# Altium schematic sheet Display.SchDoc
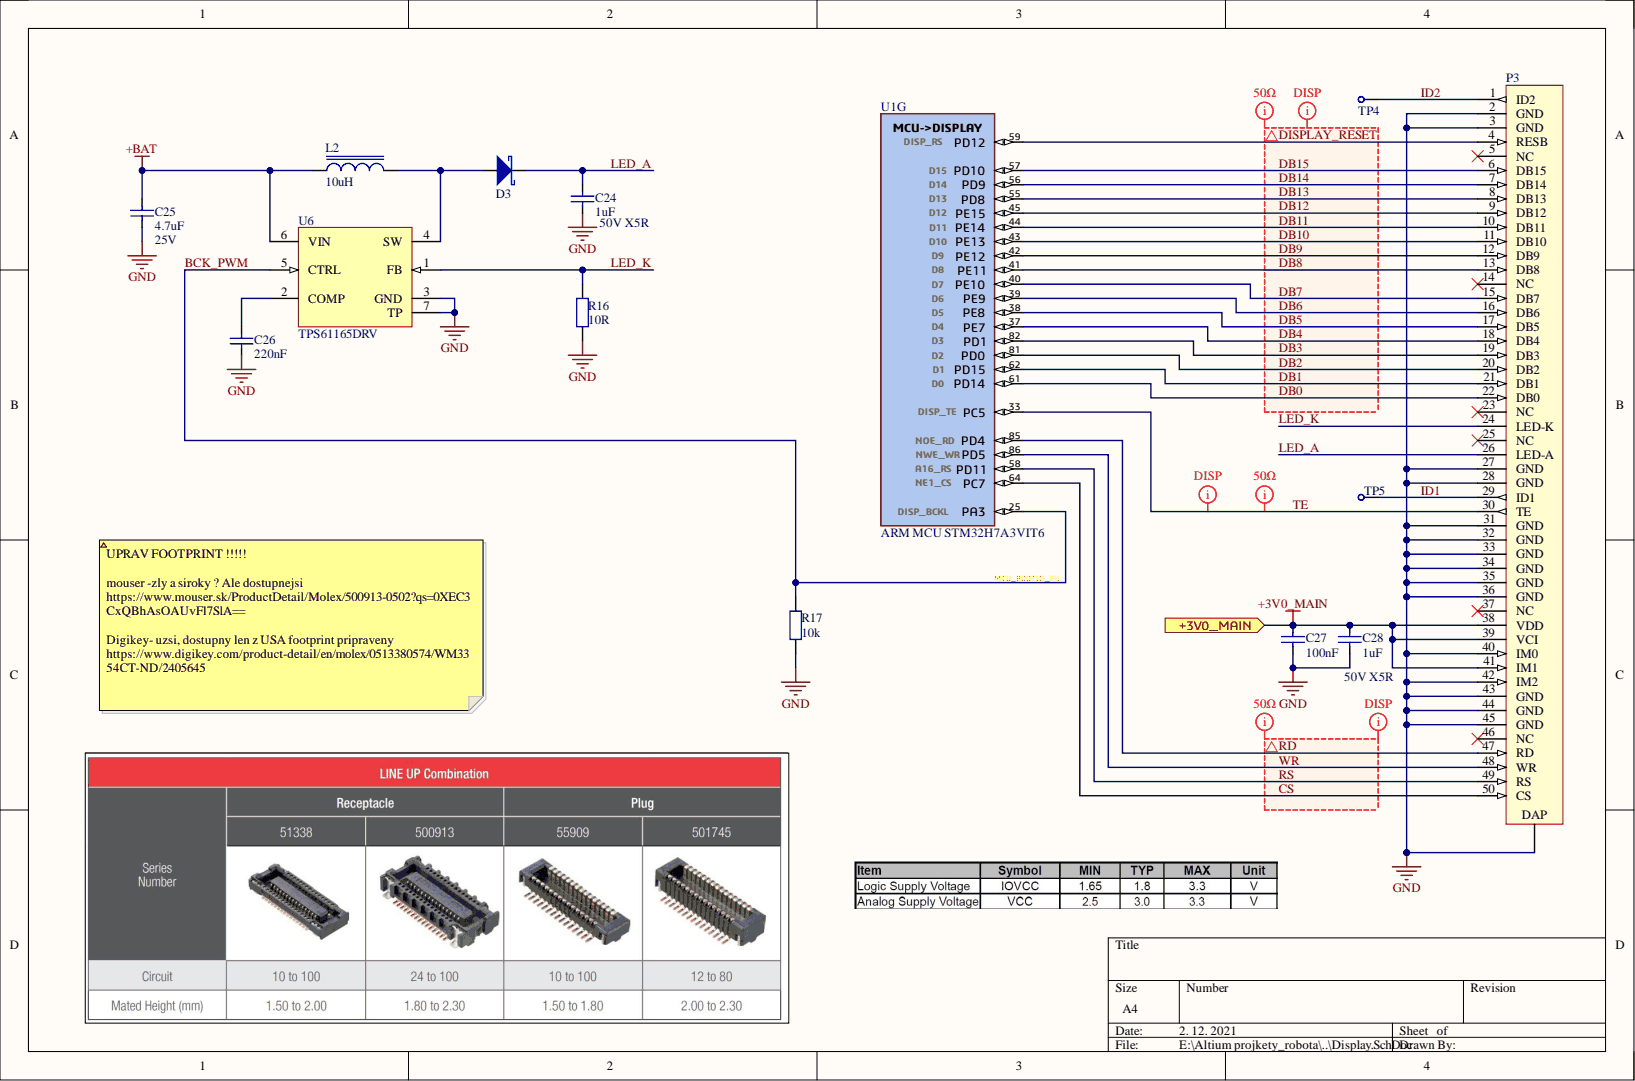
[diagram p0: Display.SchDoc]

## Parts
C24  1uF  Voltage Rating (DC): 50V  [CAPACITOR_0603_(1608)]
C25  4.7uF  Voltage: 25V  [CAPACITOR_0603_(1608)]
C26  220nF  [CAPACITOR_0603_(1608)]
C27  100nF  [CAPACITOR_0402_(1005)]
C28  1uF  Dielectric: X5R  Voltage Rating: 50V X5R  Voltage Rating (DC): 50V  [CAPACITOR_0603_(1608)]
D3  NSR10F30NXT5G  [D_DSN2_(0502)]  (value not drawn)
L2  10uH  [INDUCTOR_1210_(3225)]
P3  Connector  [MOLEX 500913-0502]  (value not drawn)
R16  10R  [RESISTOR_0603_(1608)]
R17  10k  [RESISTOR_0603_(1608)]
TP4  test point  [TP]  (value not drawn)  (no BOM)
TP5  test point  [TP]  (value not drawn)  (no BOM)
U1  ARM MCU STM32H7A3VIT6  Manufacturer Part Number: STM32H7A3VIT6  [STM-LQFP100_N - Edited]  (part G)
U6  TPS61165DRV  [WSON-6]

## Ports
+3V0_MAIN

## Nets
+BAT: C25.1 L2.1 U6.6(VIN)
GND: C24.2 C25.2 C26.1 C27.1 C28.2 P3.0 P3.2(GND) P3.3(GND) P3.27(GND) P3.28(GND) P3.31(GND) P3.32(GND) P3.33(GND) P3.34(GND) P3.35(GND) P3.36(GND) P3.40(IM0) P3.42(IM2) P3.43(GND) P3.44(GND) P3.45(GND) R16.1 R17.2 U6.3(GND) U6.7(TP)
+3V0_MAIN: C27.2 C28.1 P3.38(VDD) P3.39(VCI) P3.41(IM1) ; port +3V0_MAIN
BCK_PWM: R17.1 U1.25(PA3) U6.5(CTRL)
CS: P3.50(CS) U1.64(PC7)
DB0: P3.22(DB0) U1.61(PD14)
DB1: P3.21(DB1) U1.62(PD15)
DB2: P3.20(DB2) U1.81(PD0)
DB3: P3.19(DB3) U1.82(PD1)
DB4: P3.18(DB4) U1.37(PE7)
DB5: P3.17(DB5) U1.38(PE8)
DB6: P3.16(DB6) U1.39(PE9)
DB7: P3.15(DB7) U1.40(PE10)
DB8: P3.13(DB8) U1.41(PE11)
DB9: P3.12(DB9) U1.42(PE12)
DB10: P3.11(DB10) U1.43(PE13)
DB11: P3.10(DB11) U1.44(PE14)
DB12: P3.9(DB12) U1.45(PE15)
DB13: P3.8(DB13) U1.55(PD8)
DB14: P3.7(DB14) U1.56(PD9)
DB15: P3.6(DB15) U1.57(PD10)
DISPLAY_RESET: P3.4(RESB) U1.59(PD12)
ID1: P3.29(ID1) TP5.1
ID2: P3.1(ID2) TP4.1
LED_A: C24.1 D3.1 P3.26(LED-A)
LED_K: P3.24(LED-K) R16.2 U6.1(FB)
RD: P3.47(RD) U1.85(PD4)
RS: P3.49(RS) U1.58(PD11)
TE: P3.30(TE) U1.33(PC5)
WR: P3.48(WR) U1.86(PD5)
NetC26_2: C26.2 U6.2(COMP)
NetD3_2: D3.2 L2.2 U6.4(SW)

Not connected: P3 5(NC) 14(NC) 23(NC) 25(NC) 37(NC) 46(NC)

## Library data of the parts
C24 = CAPACITOR: Manufacturer: Taiyo Yuden; Manufacturer Part Number 1: UMK107BJ105KA-T; Dielectric: X5R; ESR: 0.28R; Tolerance: 10%; Voltage Rating: 50V X5R; Capacitance: 1uF; Case Code (Imperial): 0603; Case Code (Metric): 1608; Case/Package: 0603; Component Kind: Standard; ComponentLink1Description: https://datasheet.ciiva.com/5744/1697715-5744913.pdf?src-supplier=Farnell; ComponentLink1URL: https://datasheet.ciiva.com/5744/1697715-5744913.pdf?src-supplier=Farnell; ComponentLink2Description: https://datasheet.ciiva.com/11601/umk107bj105ka-t-ss-11601811.pdf?src-supplier=Avnet; ComponentLink2URL: https://datasheet.ciiva.com/11601/umk107bj105ka-t-ss-11601811.pdf?src-supplier=Avnet; ComponentLink3Description: https://datasheet.ciiva.com/15922/336umk107bj105ka-t-15922611.pdf?src-supplier=Onlinecomponents.com; ComponentLink3URL: https://datasheet.ciiva.com/15922/336umk107bj105ka-t-15922611.pdf?src-supplier=Onlinecomponents.com; ComponentLink4Description: https://datasheet.ciiva.com/134/umk107bj105ka-t-ss-134740.pdf?src-supplier=Digi-Key; ComponentLink4URL: https://datasheet.ciiva.com/134/umk107bj105ka-t-ss-134740.pdf?src-supplier=Digi-Key; ComponentLink5Description: https://datasheet.ciiva.com/30199/43umk107bj105ka-t-30199791.pdf?src-supplier=Onlinecomponents.com; ComponentLink5URL: https://datasheet.ciiva.com/30199/43umk107bj105ka-t-30199791.pdf?src-supplier=Onlinecomponents.com; ComponentLink6Description: https://datasheet.ciiva.com/22694/1697715-22694278.pdf?src-supplier=Element14; ComponentLink6URL: https://datasheet.ciiva.com/22694/1697715-22694278.pdf?src-supplier=Element14; ComponentLink7Description: https://datasheet.ciiva.com/7975/1697715-7975608.pdf?src-supplier=Element14; ComponentLink7URL: https://datasheet.ciiva.com/7975/1697715-7975608.pdf?src-supplier=Element14; ComponentLink8Description: https://datasheet.ciiva.com/7975/mlcc-all-e-7975898.pdf?src-supplier=Element14; ComponentLink8URL: https://datasheet.ciiva.com/7975/mlcc-all-e-7975898.pdf?src-supplier=Element14; ComponentLink9Description: https://datasheet.ciiva.com/5427/mlcc-all-e-541559-5427036.pdf?src-supplier=Mouser; ComponentLink9URL: https://datasheet.ciiva.com/5427/mlcc-all-e-541559-5427036.pdf?src-supplier=Mouser; Contact Plating: Nickel; Depth: 0.8mm; Description: CAPACITOR; Halogen Free: Halogen Free; Height: 0.8mm; Length: 1.6mm; Max Operating Temperature: 85°C; Min Operating Temperature: -55°C; Mount: Surface Mount; Number of Pins: 2; Package Quantity: 1; Packaging: Tape and Reel; Pricing 1: 10=0,14300, 100=0,06560, 500=0,04260, 1000=0,03940, 2000=0,03750, 4000=0,02830, 20000=0,02630 (EUR); Radiation Hardening: No; REACH SVHC: Unknown; RoHS: Yes; Stock 1: 372983; Supplier 1: Farnell; Supplier Part Number 1: 2112858; Termination: SMD/SMT; Thickness: 0.035inch; Width: 0.031inch; Library Name: RLCFB.SchLib
C25 = CAPACITOR: Manufacturer: Murata; Manufacturer Part Number 1: GRM188R61E475KE11D; Dielectric: X5R; ESR: 0.28R; Material: Ceramic; Tolerance: 10%; Capacitance: 4.7uF; Case Code (Imperial): 0603; Case Code (Metric): 1608; Case/Package: 0603; Component Kind: Standard; ComponentLink1Description: https://datasheet.ciiva.com/46444/1769893-46444982.pdf?src-supplier=Element14; ComponentLink1URL: https://datasheet.ciiva.com/46444/1769893-46444982.pdf?src-supplier=Element14; ComponentLink2Description: https://datasheet.ciiva.com/46444/1769893-46444983.pdf?src-supplier=Element14; ComponentLink2URL: https://datasheet.ciiva.com/46444/1769893-46444983.pdf?src-supplier=Element14; ComponentLink3Description: https://datasheet.ciiva.com/14891/partnumbering-e-01-14891102.pdf?src-supplier=Digi-Key; ComponentLink3URL: https://datasheet.ciiva.com/14891/partnumbering-e-01-14891102.pdf?src-supplier=Digi-Key; ComponentLink4Description: https://datasheet.ciiva.com/14891/partnumbering-e-01-14891103.pdf?src-supplier=Digi-Key; ComponentLink4URL: https://datasheet.ciiva.com/14891/partnumbering-e-01-14891103.pdf?src-supplier=Digi-Key; Depth: 0.8mm; Description: CAPACITOR; Height: 0.8mm; Lead Free: Lead Free; Length: 1.6mm; Max Operating Temperature: 85°C; Min Operating Temperature: -55°C; Mount: Surface Mount; Package Quantity: 4000; Packaging: Cut Tape; Pricing 1: 10=0,35700, 100=100,00000, 500=0,15900, 1000=0,12800, 2000=0,10200 (CHF); REACH SVHC: No SVHC; RoHS: Yes; Stock 1: 95119; Supplier 1: Farnell; Supplier Part Number 1: 2426959; Thickness: 0.031inch; Voltage Rating: 25V; Voltage Rating (DC): 25V; Width: 0.031inch; Library Name: RLCFB.SchLib
C26 C27 = CAPACITOR: ESR: 0.28R; Voltage Rating: 10V; Component Kind: Standard; Description: CAPACITOR; Library Name: RLCFB.SchLib
  C26: Capacitance: 220nF
  C27: Capacitance: 100nF
C28 = CAPACITOR: Manufacturer: Taiyo Yuden; Manufacturer Part Number 1: UMK107BJ105KA-T; ESR: 0.28R; Tolerance: 10%; Capacitance: 1uF; Case Code (Imperial): 0603; Case Code (Metric): 1608; Case/Package: 0603; Component Kind: Standard; ComponentLink1Description: https://datasheet.ciiva.com/5744/1697715-5744913.pdf?src-supplier=Farnell; ComponentLink1URL: https://datasheet.ciiva.com/5744/1697715-5744913.pdf?src-supplier=Farnell; ComponentLink2Description: https://datasheet.ciiva.com/11601/umk107bj105ka-t-ss-11601811.pdf?src-supplier=Avnet; ComponentLink2URL: https://datasheet.ciiva.com/11601/umk107bj105ka-t-ss-11601811.pdf?src-supplier=Avnet; ComponentLink3Description: https://datasheet.ciiva.com/15922/336umk107bj105ka-t-15922611.pdf?src-supplier=Onlinecomponents.com; ComponentLink3URL: https://datasheet.ciiva.com/15922/336umk107bj105ka-t-15922611.pdf?src-supplier=Onlinecomponents.com; ComponentLink4Description: https://datasheet.ciiva.com/134/umk107bj105ka-t-ss-134740.pdf?src-supplier=Digi-Key; ComponentLink4URL: https://datasheet.ciiva.com/134/umk107bj105ka-t-ss-134740.pdf?src-supplier=Digi-Key; ComponentLink5Description: https://datasheet.ciiva.com/30199/43umk107bj105ka-t-30199791.pdf?src-supplier=Onlinecomponents.com; ComponentLink5URL: https://datasheet.ciiva.com/30199/43umk107bj105ka-t-30199791.pdf?src-supplier=Onlinecomponents.com; ComponentLink6Description: https://datasheet.ciiva.com/22694/1697715-22694278.pdf?src-supplier=Element14; ComponentLink6URL: https://datasheet.ciiva.com/22694/1697715-22694278.pdf?src-supplier=Element14; ComponentLink7Description: https://datasheet.ciiva.com/7975/1697715-7975608.pdf?src-supplier=Element14; ComponentLink7URL: https://datasheet.ciiva.com/7975/1697715-7975608.pdf?src-supplier=Element14; ComponentLink8Description: https://datasheet.ciiva.com/7975/mlcc-all-e-7975898.pdf?src-supplier=Element14; ComponentLink8URL: https://datasheet.ciiva.com/7975/mlcc-all-e-7975898.pdf?src-supplier=Element14; ComponentLink9Description: https://datasheet.ciiva.com/5427/mlcc-all-e-541559-5427036.pdf?src-supplier=Mouser; ComponentLink9URL: https://datasheet.ciiva.com/5427/mlcc-all-e-541559-5427036.pdf?src-supplier=Mouser; Contact Plating: Nickel; Depth: 0.8mm; Description: CAPACITOR; Halogen Free: Halogen Free; Height: 0.8mm; Length: 1.6mm; Max Operating Temperature: 85°C; Min Operating Temperature: -55°C; Mount: Surface Mount; Number of Pins: 2; Package Quantity: 1; Packaging: Tape and Reel; Pricing 1: 10=0,14300, 100=0,06560, 500=0,04260, 1000=0,03940, 2000=0,03750, 4000=0,02830, 20000=0,02630 (EUR); Radiation Hardening: No; REACH SVHC: Unknown; RoHS: Yes; Stock 1: 372983; Supplier 1: Farnell; Supplier Part Number 1: 2112858; Termination: SMD/SMT; Thickness: 0.035inch; Width: 0.031inch; Library Name: RLCFB.SchLib
D3 = D_NSR10F30NXT5G: DIODE SCHOTTKY 30V 1A 2DSN; Manufacturer: ON Semiconductor; Element Configuration: Single; Forward Voltage: 470mV; Max Forward Surge Current (Ifsm): 18A; Max Power Dissipation: 1.47W; Max Reverse Current: 100uA; Max Reverse Leakage Current: 20uA; Power Dissipation: 548mW; Average Rectified Current: 1A; Case/Package: 0502; Category: Schottky Diodes; Component Kind: Standard; ComponentLink1Description: https://datasheet.ciiva.com/917/nsr10f30-d-917057.pdf?src-supplier=Digi-Key; ComponentLink1URL: https://datasheet.ciiva.com/917/nsr10f30-d-917057.pdf?src-supplier=Digi-Key; ComponentLink2Description: https://datasheet.ciiva.com/4713/nsr10f30-97625-4713884.pdf?src-supplier=Mouser; ComponentLink2URL: https://datasheet.ciiva.com/4713/nsr10f30-97625-4713884.pdf?src-supplier=Mouser; ComponentLink3Description: https://datasheet.ciiva.com/917/nsr10f30-d-917058.pdf?src-supplier=Digi-Key; ComponentLink3URL: https://datasheet.ciiva.com/917/nsr10f30-d-917058.pdf?src-supplier=Digi-Key; ComponentLink4Description: https://datasheet.ciiva.com/917/nsr10f30-d-917059.pdf?src-supplier=Digi-Key; ComponentLink4URL: https://datasheet.ciiva.com/917/nsr10f30-d-917059.pdf?src-supplier=Digi-Key; ComponentLink5Description: https://datasheet.ciiva.com/16474/nsr10f30-d-16474534.pdf?src-supplier=Future+Electronics; ComponentLink5URL: https://datasheet.ciiva.com/16474/nsr10f30-d-16474534.pdf?src-supplier=Future+Electronics; Forward Current: 1A; Lead Free: Lead Free; Library Name: BB1_SCH_Library.SchLib; Manufacturer Part Number: NSR10F30NXT5G; Max Operating Temperature: 150°C; Max Repetitive Reverse Voltage (Vrrm): 30V; Max Reverse Voltage (DC): 30V; Max Surge Current: 18A; Min Operating Temperature: -40°C; Number of Pins: 2; Package Quantity: 5000; Packaging: Tape and Reel; Peak Non-Repetitive Surge Current: 18A; Pricing 1: 1=0,44000, 10=0,32200, 100=0,18700, 1000=0,12200, 5000=0,11200, 10000=0,10300 (USD); Radiation Hardening: No; RoHS: Yes; Stock 1: 10180; Supplier 1: Mouser; Supplier Part Number 1: 863-NSR10F30NXT5G
L2 = IND_VLCF5020T: Ind Chip Wirewound 10uH 20% 1210 Emboss T/R; Manufacturer: Murata; Manufacturer Part Number: DFE322512F-100M=P2; Component Kind: Standard; Pricing 1: 1=0,91000, 10=0,80900, 100=0,57700, 500=0,42200, 1000=0,34100, 3000=0,29700 (USD); RoHS: No; Stock 1: 2824; Supplier 1: Mouser; Supplier Part Number 1: 81-DFE322512F-100MP2; Library Name: LVDS_to_LVTTL.SchLib
P3 = Molex 500913-0502: RECEPTACLE HOUSING, 0.40MM, 50WAY; Manufacturer: Molex; Manufacturer Part Number: 5009130502; Current Rating: 300mA; Glow Wire Compliant: Non-compliant; Mated Stacking Heights: 1.8mm; Max Voltage Rating (AC): 50V; Number of Circuits: 50; Pitch: 0.4mm; Category: Headers and Wire Housings; Component Kind: Standard; ComponentLink1Description: https://datasheet.ciiva.com/401/5009130242-sd-401826.pdf?src-supplier=Digi-Key; ComponentLink1URL: https://datasheet.ciiva.com/401/5009130242-sd-401826.pdf?src-supplier=Digi-Key; ComponentLink2Description: https://datasheet.ciiva.com/5130/5009130502-pcb-receptacles-155372-5130402.pdf?src-supplier=Mouser; ComponentLink2URL: https://datasheet.ciiva.com/5130/5009130502-pcb-receptacles-155372-5130402.pdf?src-supplier=Mouser; ComponentLink3Description: https://datasheet.ciiva.com/10071/5009130502-pcb-receptacles-10071672.pdf?src-supplier=Avnet; ComponentLink3URL: https://datasheet.ciiva.com/10071/5009130502-pcb-receptacles-10071672.pdf?src-supplier=Avnet; ComponentLink4Description: https://datasheet.ciiva.com/1439/1711402-1439916.pdf?src-supplier=Element14; ComponentLink4URL: https://datasheet.ciiva.com/1439/1711402-1439916.pdf?src-supplier=Element14; Connector Type: Receptacle; Contact Material: Bronze; Contact Plating: Gold; Depth: 4.2mm; ELV: Compliant; Gender: Receptacle; Halogen Free: Low Halogen; Housing Color: Black; Housing Material: Thermoplastic; Length: 13.1mm; Library Name: BB1_SCH_Library.SchLib; Material: Bronze; Max Operating Temperature: 85°C; Max Voltage Rating (DC): 50V; Min Operating Temperature: -25°C; Mount: Surface Mount; Number of Contacts: 50; Number of Positions: 50; Number of Rows: 2; Orientation: Vertical; Package Quantity: 1500; Packaging: Tape and Reel; Radiation Hardening: No; REACH SVHC: No SVHC; RoHS: Yes; Supplier 1: Mouser; Supplier Part Number 1: 538-500913-0502; Termination: Solder; Voltage Rating (AC): 50V
R16 R17 = RESISTOR: Power Rating: 500mW; Component Kind: Standard; Description: RESISTOR; Library Name: RLCFB.SchLib
  R16: Resistance: 10R
  R17: Resistance: 10k
U1 = STM32H7A3VIT6_LQFP100: STM32H7A3VIT6 -  32-bit Arm® Cortex®-M7 280 MHz MCUs, up to 2-Mbyte Flash memory, 1.4Mbyte RAM, 46 com. and analog interfaces; Manufacturer: STMicroelectronics; Category: Microcontrollers; Component Kind: Standard; ComponentLink1Description: Datasheet; ComponentLink1URL: https://www.st.com/resource/en/datasheet/stm32h7a3vi.pdf; Library Name: BB1_SCH_Library.SchLib; RoHS: No; Supplier 1: Mouser; Supplier Part Number 1: 511-STM32H7A3VIT6
U6 = TPS61165DRVR: TEXAS INSTRUMENTS TPS61165DRVR            WHITE LED DRIVER, BOOST, SON-6, FULL REEL; Frequency: 1.5MHz; High Level Output Current: 100uA; Max Input Voltage: 18V; Max Power Dissipation: 1.54W; Max Supply Current: 2.3mA; Min Input Voltage: 3V; Number of Channels: 1; Number of Segments: 10; Output Current: 1.2A; Output Voltage: 38V; Quiescent Current: 1.5mA; Switching Frequency: 1.2MHz; Category: LED Drivers; Component Kind: Standard; ComponentLink1Description: https://datasheet.ciiva.com/7977/1749528-7977713.pdf?src-supplier=Element14; ComponentLink1URL: https://datasheet.ciiva.com/7977/1749528-7977713.pdf?src-supplier=Element14; ComponentLink2Description: https://datasheet.ciiva.com/15135/getdatasheetpartid-302988-15135127.pdf?src-supplier=Verical; ComponentLink2URL: https://datasheet.ciiva.com/15135/getdatasheetpartid-302988-15135127.pdf?src-supplier=Verical; ComponentLink3Description: https://datasheet.ciiva.com/26878/tps61165drvr-26878277.pdf?src-supplier=IIIC; ComponentLink3URL: https://datasheet.ciiva.com/26878/tps61165drvr-26878277.pdf?src-supplier=IIIC; ComponentLink4Description: https://datasheet.ciiva.com/3164/tps61165-3164135.pdf?src-supplier=Avnet; ComponentLink4URL: https://datasheet.ciiva.com/3164/tps61165-3164135.pdf?src-supplier=Avnet; ComponentLink5Description: https://datasheet.ciiva.com/1945/tps61165-1945578.pdf?src-supplier=Digi-Key; ComponentLink5URL: https://datasheet.ciiva.com/1945/tps61165-1945578.pdf?src-supplier=Digi-Key; ComponentLink6Description: https://datasheet.ciiva.com/1945/tps61165-1945579.pdf?src-supplier=Digi-Key; ComponentLink6URL: https://datasheet.ciiva.com/1945/tps61165-1945579.pdf?src-supplier=Digi-Key; ComponentLink7Description: https://datasheet.ciiva.com/1945/tps61165-1945580.pdf?src-supplier=Digi-Key; ComponentLink7URL: https://datasheet.ciiva.com/1945/tps61165-1945580.pdf?src-supplier=Digi-Key; ComponentLink8Description: https://datasheet.ciiva.com/28506/1887503-28506783.pdf?src-supplier=Element14; ComponentLink8URL: https://datasheet.ciiva.com/28506/1887503-28506783.pdf?src-supplier=Element14; Lead Free: Lead Free; Library Name: BB1_SCH_Library.SchLib; Low Level Output Current: 100uA; Manufacturer: Texas Instruments; Manufacturer Part Number: TPS61165DRVR; Max Operating Temperature: 85°C; Max Supply Voltage: 18V; Min Operating Temperature: -40°C; Min Supply Voltage: 3V; Number of Outputs: 1; Number of Pins: 6; Package Quantity: 1; Packaging: Tape and Reel; Power Dissipation: 1.54W; Pricing 1: 1=1,82000, 10=1,54000, 100=1,24000, 250=1,08000, 500=1,05000, 1000=0,83200 (USD); Radiation Hardening: No; REACH SVHC: No SVHC; RoHS: Yes; Stock 1: 8728; Supplier 1: Mouser; Supplier Part Number 1: 595-TPS61165DRVR; Topology: Boost
TP4 TP5 = test point: Library Name: BB1_SCH_Library.SchLib
